# Altium schematic sheet 继电器驱动模块-底板 SCHV1.0-α PM-Actuator-RBoard.SchDoc
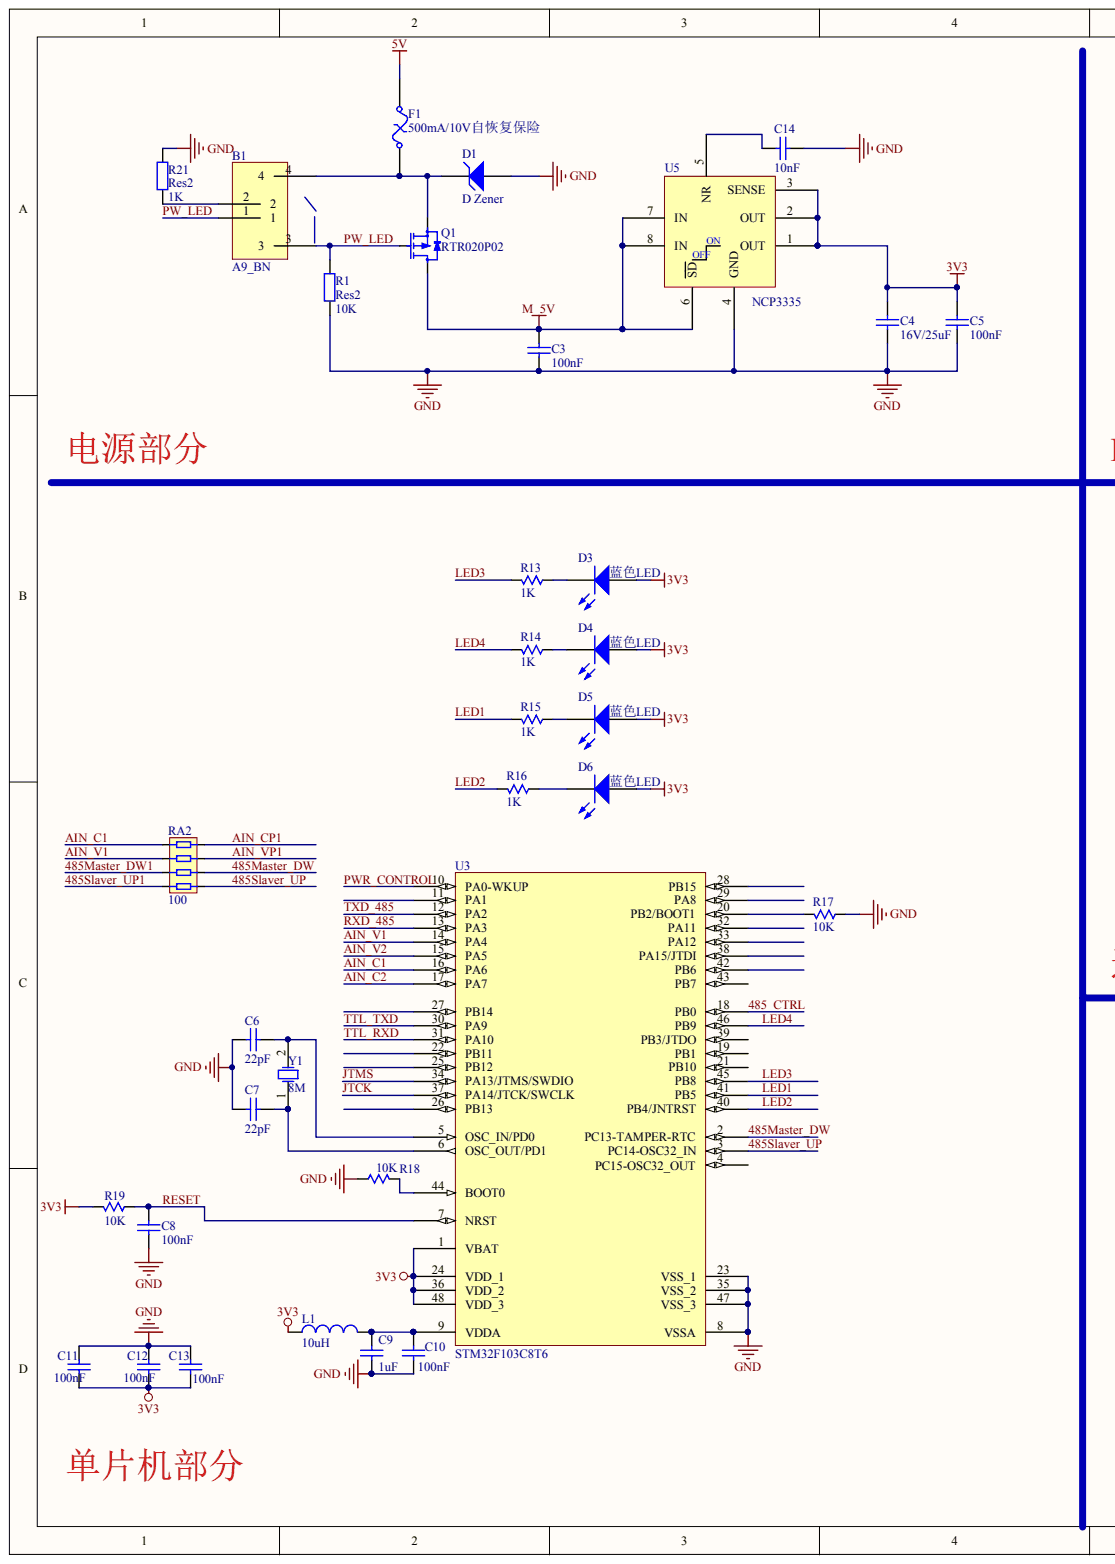
[diagram p0: 继电器驱动模块-底板 SCHV1.0-α PM-Actuator-RBoard.SchDoc, left]
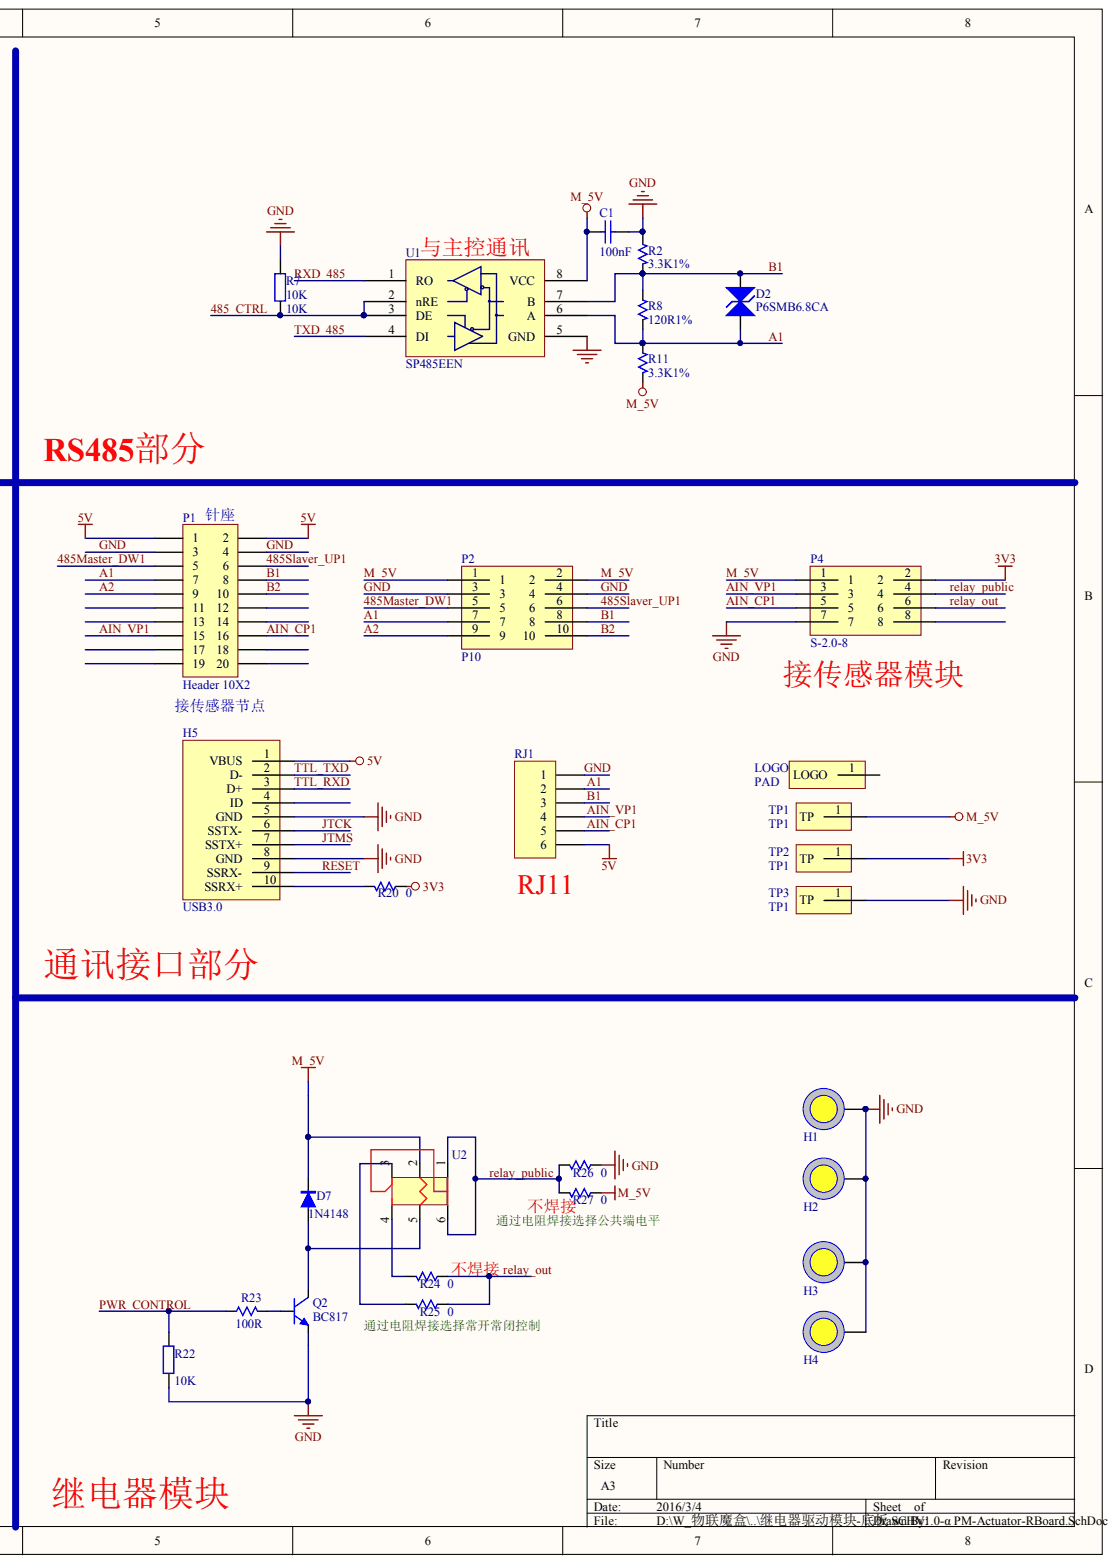
[diagram p1: 继电器驱动模块-底板 SCHV1.0-α PM-Actuator-RBoard.SchDoc, right]

## Parts
B1  A9_BN  [卧式带灯按键]
C1  100nF  [C0603]
C3  100nF  [C0603]
C4  16V/25uF  [T_C]
C5  100nF  [C0603]
C6  22pF  [C0603]
C7  22pF  [C0603]
C8  100nF  [C0603]
C9  1uF  [C0603]
C10  100nF  [C0603]
C11  100nF  [C0603]
C12  100nF  [C0603]
C13  100nF  [C0603]
C14  10nF  [C0603]
D1  D Zener  [SMB]
D2  P6SMB6.8CA  [SMB]
D3  蓝色LED  [LED0805]
D4  蓝色LED  [LED0805]
D5  蓝色LED  [LED0805]
D6  蓝色LED  [LED0805]
D7  1N4148  [SOD-80]
F1  500mA/10V自恢复保险  [F1812]
H1  H1  [HT-M3.6非金属化孔]
H2  H1  [HT-M3.6非金属化孔]
H3  H1  [HT-M3.6非金属化孔]  (value not drawn)
H4  H1  [HT-M3.6非金属化孔]  (value not drawn)
H5  USB3.0  [Micro USB3.0-B]
L1  10uH  [R0603]
LOGO  PAD  [Design info]
P1  Header 10X2  [2.0间距2x10加高型H9.9针座]
P2  P10  [PORT10]
P4  S-2.0-8  [S-2.0-8]
Q1  RTR020P02  [SOT-23]
Q2  BC817  [SOT-23]
R1  Res2  value 10K  [R0603]
R2  3.3K1%  [R0603]
R7  10K  [R0603]
R8  120R1%  [R0603]
R11  3.3K1%  [R0603]
R13  1K  [R0603]
R14  1K  [R0603]
R15  1K  [R0603]
R16  1K  [R0603]
R17  10K  [R0603]
R18  10K  [R0603]
R19  10K  [R0603]
R20  0  [R0603]
R21  Res2  value 1K  [R0603]
R22  value 10K  [R0603]  (hidden comment: 10k 0603)
R23  100R  [R0603]
R24  0  [R0603]
R25  0  [R0603]
R26  0  [R0603]
R27  0  [R0603]
RA2  100  [RA0603-4]
RJ1  Header 6  [RJ12]  (value not drawn)
TP1  TP1  [TP1]
TP2  TP1  [TP1]
TP3  TP1  [TP1]
U1  SP485EEN  [SO8-150]
U2  Ralay_mini  [Ralay_mini]  (value not drawn)
U3  STM32F103C8T6  [LQFP48_N]
U5  NCP3335  [Micro8]
Y1  8M  [CRYSTAL]

## Nets
3V3: C4.2 C5.2 C11.2 C12.2 C13.2 D3.1 D4.1 D5.1 D6.1 L1.1 P4.2 R19.1 R20.1 TP2.1 U3.1(VBAT) U3.24(VDD_1) U3.36(VDD_2) U3.48(VDD_3) U5.1(OUT) U5.2(OUT) U5.3(SENSE)
5V: F1.2 H5.1(VBUS) P1.1 P1.2 RJ1.6
485_CTRL: R7.1 U1.2(nRE) U1.3(DE) U3.18(PB0)
485MASTER_DW: RA2.3 U3.2(PC13-TAMPER-RTC)
485MASTER_DW1: P1.5 P2.5 RA2.4
485SLAVER_UP: RA2.1 U3.3(PC14-OSC32_IN)
485SLAVER_UP1: P1.6 P2.6 RA2.2
A1: D2.1 P1.7 P2.7 R8.1 R11.2 RJ1.2 U1.6(A)
A2: P1.9 P2.9
AIN_C1: RA2.8 U3.16(PA6)
AIN_C2: U3.17(PA7)
AIN_CP1: P1.16 P4.5 RA2.7 RJ1.5
AIN_V1: RA2.6 U3.14(PA4)
AIN_V2: U3.15(PA5)
AIN_VP1: P1.15 P4.3 RA2.5 RJ1.4
B1: D2.2 P1.8 P2.8 R2.1 R8.2 RJ1.3 U1.7(B)
B2: P1.10 P2.10
GND: C1.2 C3.1 C4.1 C5.1 C6.1 C7.1 C8.2 C9.1 C10.2 C11.1 C12.1 C13.1 C14.2 D1.1 H1.1 H2.1 H3.1 H4.1 H5.5(GND) H5.8(GND) P1.3 P1.4 P2.3 P2.4 P4.7 Q2.2 R1.1 R2.2 R7.2 R17.1 R18.1 R21.2 R22.1 R26.1 RJ1.1 TP3.1 U1.5(GND) U3.8(VSSA) U3.23(VSS_1) U3.35(VSS_2) U3.47(VSS_3) U5.4(GND)
JTCK: H5.6(SSTX-) U3.37(PA14/JTCK/SWCLK)
JTMS: H5.7(SSTX+) U3.34(PA13/JTMS/SWDIO)
LED1: R15.1 U3.41(PB5)
LED2: R16.1 U3.40(PB4/JNTRST)
LED3: R13.1 U3.45(PB8)
LED4: R14.1 U3.46(PB9)
M_5V: C1.1 C3.2 D7.2 P2.1 P2.2 P4.1 Q1.3 R11.1 R27.1 TP1.1 U1.8(VCC) U2.2 U5.6(SD) U5.7(IN) U5.8(IN)
PW_LED: B1.1 B1.3 Q1.1 R1.2
PWR_CONTROL: R22.2 R23.2 U3.10(PA0-WKUP)
RELAY_OUT: P4.6 R24.1 R25.1
RELAY_PUBLIC: P4.4 R26.2 R27.2 U2.1 U2.6
RESET: C8.1 H5.9(SSRX-) R19.2 U3.7(NRST)
RXD_485: U1.1(RO) U3.13(PA3)
TTL_RXD: H5.3(D+) U3.31(PA10)
TTL_TXD: H5.2(D-) U3.30(PA9)
TXD_485: U1.4(DI) U3.12(PA2)
NetB1_2: B1.2 R21.1
NetB1_4: B1.4 D1.2 F1.1 Q1.2
NetC6_2: C6.2 U3.5(OSC_IN/PD0) Y1.2
NetC7_2: C7.2 U3.6(OSC_OUT/PD1) Y1.1
NetC9_2: C9.2 C10.1 L1.2 U3.9(VDDA)
NetC14_1: C14.1 U5.5(NR)
NetD3_2: D3.2 R13.2
NetD4_2: D4.2 R14.2
NetD5_2: D5.2 R15.2
NetD6_2: D6.2 R16.2
NetD7_1: D7.1 Q2.3 U2.5
NetH5_10: H5.10(SSRX+) R20.2
NetQ2_1: Q2.1 R23.1
NetR17_2: R17.2 U3.20(PB2/BOOT1)
NetR18_2: R18.2 U3.44(BOOT0)
NetR24_2: R24.2 U2.4
NetR25_2: R25.2 U2.3

Not connected: H5 4(ID); LOGO 1; P1 11 12 13 14 17 18 19 20; P4 8; U3 4(PC15-OSC32_OUT) 11(PA1) 19(PB1) 21(PB10) 22(PB11) 25(PB12) 26(PB13) 27(PB14) 28(PB15) 29(PA8) 32(PA11) 33(PA12) 38(PA15/JTDI) 39(PB3/JTDO) 42(PB6) 43(PB7)

## Library data of the parts
C1 C10-C13 = CAP: Capacitor; Component Kind: Standard; Library Name: Miscellaneous Devices.IntLib; Published: 8-Jun-2000; Revision: July-2002: Re-released for DXP Platform.; Signal Integrity: Cap; Simulation: CAP; Value: 100nF; Publisher: Altium Limited
C3-C9 C14 = Cap: Capacitor; Component Kind: Standard; Library Name: Miscellaneous Devices.IntLib; Published: 8-Jun-2000; Signal Integrity: Cap; Simulation: CAP; Publisher: Altium Limited
  C3 C5 C8: Revision: July-2002: Re-released for DXP Platform.; Value: 100nF
  C4: Revision: July-2002: Re-released for DXP Platform.; Value: 16V/25uF
  C6 C7: Revision: July-2002: Re-released for DXP Platform.; Value: 22pF
  C9: Revision: July-2002: Re-released for DXP Platform.; Value: 1uF
  C14: LatestRevisionDate: 17-Jul-2002; LatestRevisionNote: Re-released for DXP Platform.; PackageReference: RAD-0.3; Value: 10nF
D1 D2 = D Zener: Zener Diode; Component Kind: Standard; LatestRevisionDate: 17-Jul-2002; LatestRevisionNote: Re-released for DXP Platform.; Library Name: holder_ctrl.SCHLIB; PackageReference: DIODE-0.7; Published: 8-Jun-2000; Signal Integrity: D Zener; Simulation: ZENER; Publisher: Altium Limited
  D1: PackageDescription: Diode, Thru-Hole; 2 Leads; 0.7 in Pin Spacing
D3-D6 = LED0: Typical INFRARED GaAs LED; Component Kind: Standard; LatestRevisionDate: 08-Jul-2005; LatestRevisionNote: Mech Layer 1 removed.; Library Name: Miscellaneous Devices.IntLib; PackageReference: LED-0; Published: 8-Jun-2000; Simulation: LED0; Publisher: Altium Limited
D7 = Diode: 1N4148表贴二极管 黑色 2个焊盘间距3.4mm; Component Kind: Standard; Library Name: UP-ROBOT Devices.SchLib
F1 = Fuse Thermal: Thermal Fuse; Component Kind: Standard; LatestRevisionDate: 17-Jul-2002; LatestRevisionNote: Re-released for DXP Platform.; Library Name: Miscellaneous Devices.IntLib; PackageReference: PIN-W2/E2.8; Published: 24-Mar-1999; Publisher: Altium Limited
L1 = Inductor: Code_IEC: 1005; Code_JEITA: 0402; Component Kind: Standard; Description: Inductor; LatestRevisionDate: 17-Jul-2002; LatestRevisionNote: Re-released for DXP Platform.; Library Name: Miscellaneous Devices.IntLib; PackageReference: 0402-A; Published: 8-Jun-2000; Signal Integrity: Inductor; Simulation: INDUCTOR; Value: 10uH; Publisher: Altium Limited
P1 = Header 10X2: Header, 10-Pin, Dual row; Component Kind: Standard; LatestRevisionDate: 17-Jul-2002; LatestRevisionNote: Re-released for DXP Platform.; Library Name: Miscellaneous Connectors.IntLib; Signal Integrity: Connector; Publisher: Altium Limited
Q1 = P-MOS_1: Component Kind: Standard; Library Name: sensor_v2.0.SchLib
Q2 = NPN: BC817 表贴npn三极管 封装SOT-23; Code_JEDEC: TO-92A; Component Kind: Standard; ComponentLink1Description: Manufacturer Link; ComponentLink1URL: http://www.fairchildsemi.com/; ComponentLink2Description: Datasheet; ComponentLink2URL: http://www.fairchildsemi.com/ds/2N%2F2N3904.pdf; DatasheetDocument: 1997; LatestRevisionDate: 15-Jan-2003; LatestRevisionNote: PCB Footprint 'BCY-W3/D4.7' replaced; PackageDocument: Sep-1998; PackageReference: TO-92A; Published: 8-Jun-2000; Signal Integrity: 2N3904; Simulation: 2N3904; Library Name: UICC Devices.SchLib; Publisher: Altium Limited
R1 R7 R21 = Res2: Resistor; Component Kind: Standard; LatestRevisionDate: 17-Jul-2002; LatestRevisionNote: Re-released for DXP Platform.; Library Name: Miscellaneous Devices.IntLib; PackageDescription: Axial Device, Thru-Hole; 2 Leads; 0.4 in Pin Spacing; PackageReference: AXIAL-0.4; Published: 8-Jun-2000; Signal Integrity: Res; Simulation: RESISTOR; Publisher: Altium Limited
  R7: Value: 10K
R2 R8 R11 R13-R20 R24-R27 = Res: Resistor; Component Kind: Standard; LatestRevisionDate: 04-Mar-2005; LatestRevisionNote: PCB Footprint replaced.; PackageReference: J1-0603; Published: 8-Jun-2000; Publisher: Altium Limited
  R2 R11: Value: 3.3K1%
  R8: Value: 120R1%
  R13-R16: Signal Integrity: Res; Simulation: RESISTOR; Value: 1K
  R17 R18: Signal Integrity: Res; Simulation: RESISTOR; Value: 10K
  R19: Library Name: Temp.SchLib; Signal Integrity: Res; Simulation: RESISTOR; Value: 10K
  R20 R24-R27: Signal Integrity: Res; Simulation: RESISTOR; Value: 0
  R13-R18 R20 R24-R27: Library Name: UP-Robot.SchLib
R22 = Res2: 电阻10K 5%表贴0603; Component Kind: Standard; LatestRevisionDate: 17-Jul-2002; LatestRevisionNote: Re-released for DXP Platform.; Library Name: Miscellaneous Devices.IntLib; PackageDescription: Axial Device, Thru-Hole; 2 Leads; 0.4 in Pin Spacing; PackageReference: AXIAL-0.4; Published: 8-Jun-2000; Signal Integrity: Res; Simulation: RESISTOR; Publisher: Altium Limited
R23 = Res: 电阻100欧 5%表贴0603; Component Kind: Standard; LatestRevisionDate: 17-Jul-2002; LatestRevisionNote: Re-released for DXP Platform.; PackageReference: AXIAL-0.3; Published: 8-Jun-2000; Signal Integrity: Res; Simulation: RESISTOR; Value: 100R; Library Name: UICC Devices.SchLib; Publisher: Altium Limited
RA2 = RA4: Component Kind: Standard; Library Name: UICC Devices.SchLib
RJ1 = Header 6: Header, 6-Pin; Component Kind: Standard; LatestRevisionDate: 17-Jul-2002; LatestRevisionNote: Re-released for DXP Platform.; Library Name: Miscellaneous Connectors.IntLib; Signal Integrity: Connector; Publisher: Altium Limited
U1 = MAX485: Component Kind: Standard; Library Name: Industrial_node .SCHLIB
U2 = Ralay_mini: HT4100F-DC5V 黄色6个管脚直插继电器; Component Kind: Standard; Library Name: GreenHouse.SchLib
U3 = STM32F103C8T6: STM32 ARM-based 32-bit MCU with 64 Kbytes Flash, 48-pin LQFP, Industrial Temperature; Component Kind: Standard; ComponentLink1Description: Manufacturer Link; ComponentLink1URL: www.st.com/stm32; ComponentLink2Description: Datasheet; ComponentLink2URL: http://www.st.com/stonline/products/literature/ds/13587.pdf; DatasheetVersion: rev6, Mar-2008; Library Name: ST Microcontroller 32-Bit STM32.IntLib; PackageDescription: 48-Pin Low-Profile Quad Flat Pack, 7 x 7 mm Body, 0.5 mm Pitch (LQFP48); PackageReference: LQFP48; PackageVersion: Mar-2008; Published: 30-May-2008; Publisher: Altium Limited
U5 = NCP3335ADM: Component Kind: Standard; Description: NCP3335; Library Name: NodePCB.SchLib
Y1 = XTAL: Crystal Oscillator; Component Kind: Standard; Library Name: Miscellaneous Devices.IntLib; Published: 8-Jun-2000; Revision: July-2002: Re-released for DXP Platform.; Simulation: XTAL; Publisher: Altium Limited
B1 = A9_BN: Component Kind: Standard; Library Name: sensor_v2.0.SchLib
H1-H4 = H1: Component Kind: Standard; Library Name: UICC Devices.SchLib
H5 = USB3.0: Component Kind: Standard; Library Name: sensor_v2.0.SchLib
LOGO = PAD: Component Kind: Standard; Library Name: sensor_v2.0.SchLib
P2 = P10: Component Kind: Standard; Library Name: sensor_v2.0.SchLib
P4 = S-2.0-8: Component Kind: Standard; Library Name: sensor_v2.0.SchLib
TP1-TP3 = TP1: Component Kind: Standard; Library Name: sensor_v2.0.SchLib
